# Altium schematic sheet MPD_MINI_DEMO_B1.SchDoc(MPD_MINI_DEMO_B1)
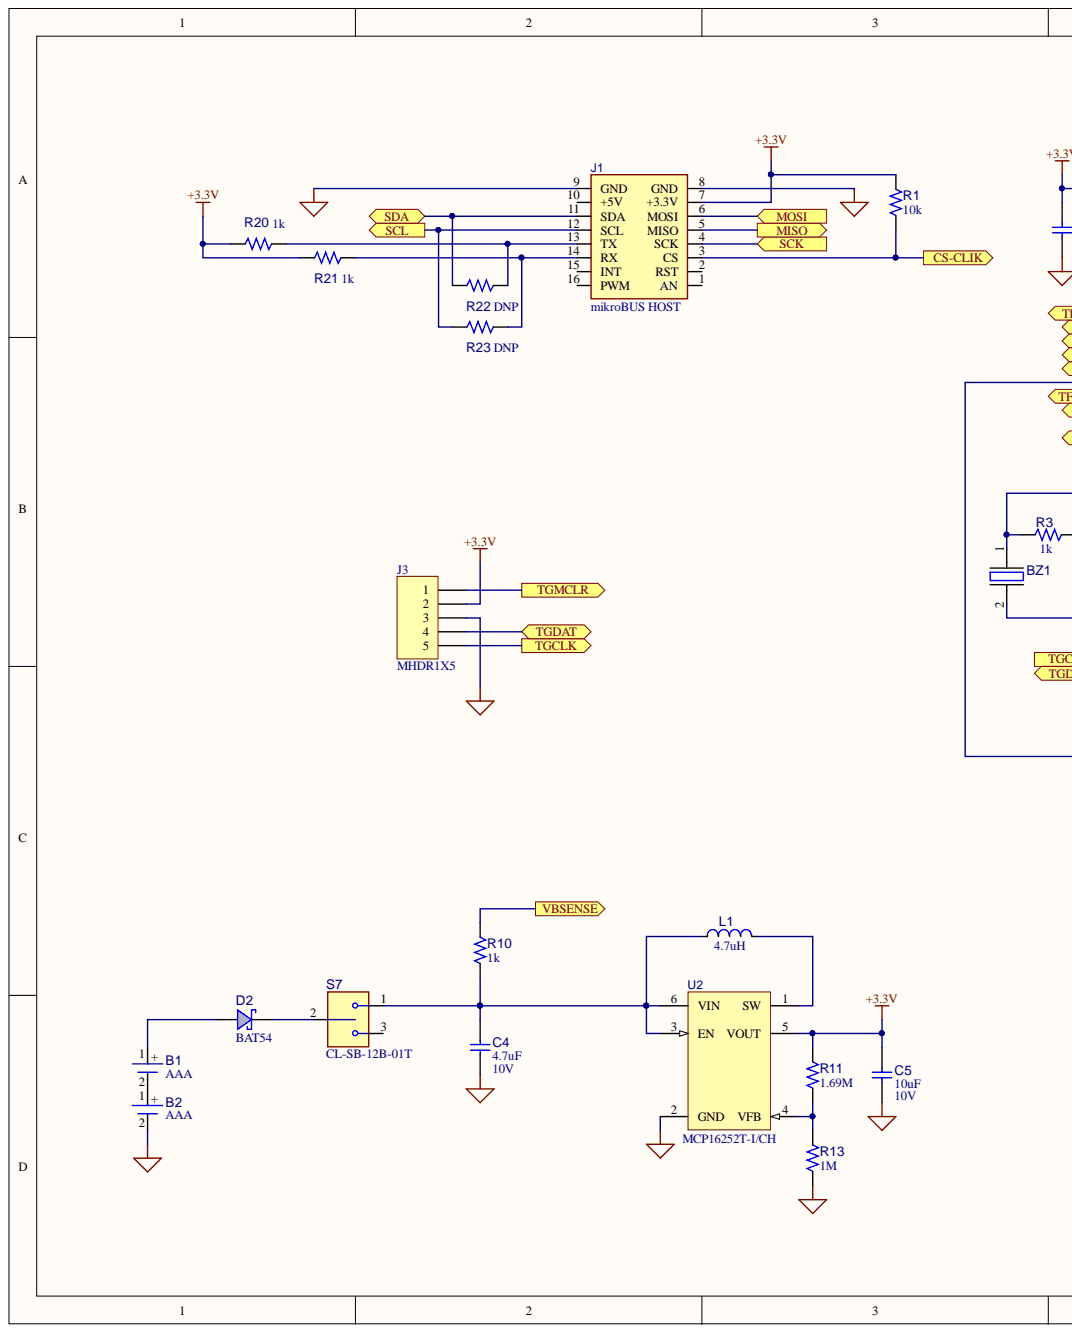
[diagram p0: MPD_MINI_DEMO_B1.SchDoc, left]
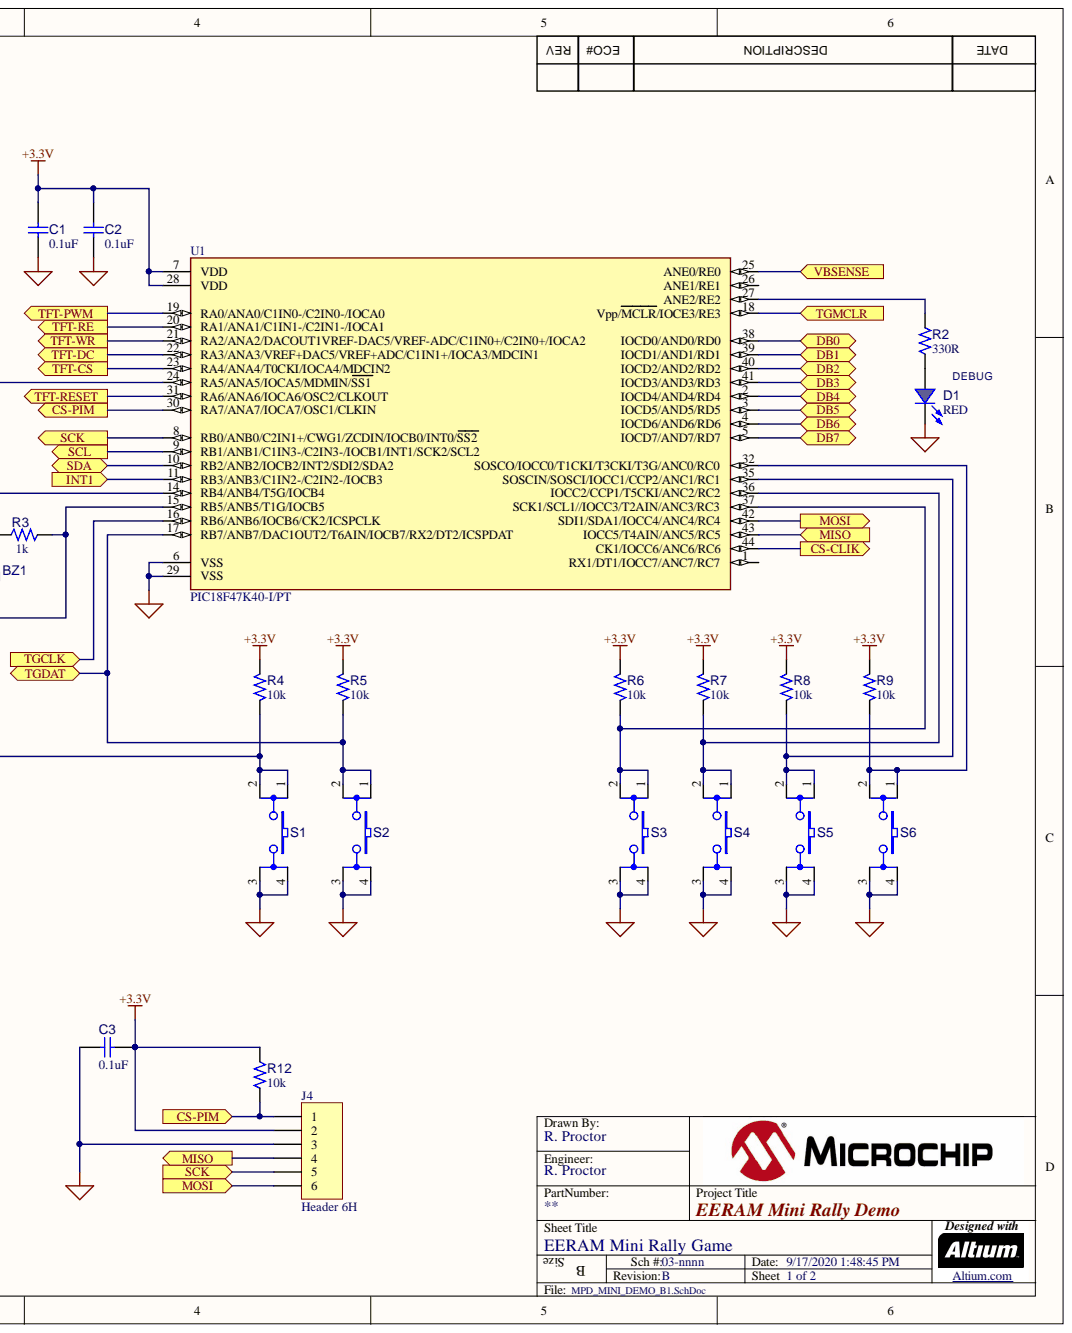
[diagram p1: MPD_MINI_DEMO_B1.SchDoc, right]

## Parts
B1  value AAA  [BATT_HOLDER_1020]  (hidden comment: Battery 2 Pin)
B2  value AAA  [BATT_HOLDER_1020]  (hidden comment: Battery 2 Pin)
BZ1  Buzzer  [BUZZER_PS1240P02CT3]  (value not drawn)
C1  value 0.1uF  [CAP_C_0603]  (hidden comment: Capacitor NP)
C2  value 0.1uF  [CAP_C_0603]  (hidden comment: Capacitor NP)
C3  value 0.1uF  [CAP_C_0603]  (hidden comment: Capacitor NP)
C4  value 4.7uF  Voltage: 10V  [CAP_C_0603]  (hidden comment: Capacitor NP)
C5  value 10uF  Voltage: 10V  [CAP_C_0603]  (hidden comment: Capacitor NP)
D1  value RED  [LED_0603_RED]  (hidden comment: LED)
D2  value BAT54  [SOT-23-3]  (hidden comment: Diode Schottky SOT-23-3)
J1  value mikroBUS HOST  [SOCKET_mikroBUS_F_2.54_2x8_V_25.4x21]  (hidden comment: SOCKET mikroBUS HOST)
J3  MHDR1X5  [MHDR1X5]
J4  Header 6H  [HDR1X6H]
L1  value 4.7uH  [IND_C_2518]  (hidden comment: Inductor)
R1  value 10k  [RES_C_0603]  (hidden comment: Resistor)
R2  value 330R  [RES_C_0603]  (hidden comment: Resistor)
R3  value 1k  [RES_C_0603]  (hidden comment: Resistor)
R4  value 10k  [RES_C_0603]  (hidden comment: Resistor)
R5  value 10k  [RES_C_0603]  (hidden comment: Resistor)
R6  value 10k  [RES_C_0603]  (hidden comment: Resistor)
R7  value 10k  [RES_C_0603]  (hidden comment: Resistor)
R8  value 10k  [RES_C_0603]  (hidden comment: Resistor)
R9  value 10k  [RES_C_0603]  (hidden comment: Resistor)
R10  value 1k  [RES_C_0603]  (hidden comment: Resistor)
R11  value 1.69M  [RES_C_0603]  (hidden comment: Resistor)
R12  value 10k  [RES_C_0603]  (hidden comment: Resistor)
R13  value 1M  [RES_C_0603]  (hidden comment: Resistor)
R20  value 1k  [RES_C_0603]  (hidden comment: Resistor)
R21  value 1k  [RES_C_0603]  (hidden comment: Resistor)
R22  value DNP  [RES_C_0603]  (hidden comment: Resistor)
R23  value DNP  [RES_C_0603]  (hidden comment: Resistor)
S1  Switch 4 Pin  [SW_TACT_SMD_B3S-1000]  (value not drawn)
S2  Switch 4 Pin  [SW_TACT_SMD_B3S-1000]  (value not drawn)
S3  Switch 4 Pin  [SW_TACT_SMD_B3S-1000]  (value not drawn)
S4  Switch 4 Pin  [SW_TACT_SMD_B3S-1000]  (value not drawn)
S5  Switch 4 Pin  [SW_TACT_SMD_B3S-1000]  (value not drawn)
S6  Switch 4 Pin  [SW_TACT_SMD_B3S-1000]  (value not drawn)
S7  Manufacturer Part Number 1: CL-SB-12B-01T  [SW_SLIDE_SMD_CL-SB-12B-01T]  (hidden comment: Switch 3 Pin)
U1  value PIC18F47K40-I/PT  [TQFP-44_10x10]  (hidden comment: PIC18(L)F4XK40 TQFP-44)
U2  MCP16252  Manufacturer Part Number 1: MCP16252T-I/CH  [SOT-23-6]

## Ports
CS-CLIK, CS-PIM, DB0, DB1, DB2, DB3, DB4, DB5, DB6, DB7, INT1, MISO, MOSI, SCK, SCL, SDA, TFT-DC, TFT-PWM, TFT-RE, TFT-RESET, TFT-WR, TFT-~{CS}, TGCLK, TGDAT, TGMCLR, VBSENSE

## Nets
+3.3V: C1.1 C2.1 C3.1 C5.1 J1.7(+3.3V) J3.2 J4.2 R1.1 R4.1 R5.1 R6.1 R7.1 R8.1 R9.1 R11.1 R12.1 R20.1 R21.1 U1.7(VDD) U1.28(VDD) U2.5(VOUT)
GND: B2.2 C1.2 C2.2 C3.2 C4.2 C5.2 D1.2 J1.8(GND) J1.9(GND) J3.3 J4.3 R13.2 S1.3 S1.4 S2.3 S2.4 S3.3 S3.4 S4.3 S4.4 S5.3 S5.4 S6.3 S6.4 U1.6(VSS) U1.29(VSS) U2.2(GND)
CS-CLIK: J1.3(CS) R1.2 U1.44(CK1/IOCC6/ANC6/RC6) ; port CS-CLIK
CS-PIM: J4.1 R12.2 U1.30(RA7/ANA7/IOCA7/OSC1/CLKIN) ; port CS-PIM
DB0: U1.38(IOCD0/AND0/RD0) ; port DB0
DB1: U1.39(IOCD1/AND1/RD1) ; port DB1
DB2: U1.40(IOCD2/AND2/RD2) ; port DB2
DB3: U1.41(IOCD3/AND3/RD3) ; port DB3
DB4: U1.2(IOCD4/AND4/RD4) ; port DB4
DB5: U1.3(IOCD5/AND5/RD5) ; port DB5
DB6: U1.4(IOCD6/AND6/RD6) ; port DB6
DB7: U1.5(IOCD7/AND7/RD7) ; port DB7
INT1: U1.11(RB3/ANB3/C1IN2-/C2IN2-/IOCB3) ; port INT1
MISO: J1.5(MISO) J4.4 U1.43(IOCC5/T4AIN/ANC5/RC5) ; port MISO
MOSI: J1.6(MOSI) J4.6 U1.42(SDI1/SDA1/IOCC4/ANC4/RC4) ; port MOSI
SCK: J1.4(SCK) J4.5 U1.8(RB0/ANB0/C2IN1+/CWG1/ZCDIN/IOCB0/INT0/SS2) ; port SCK
SCL: J1.12(SCL) R23.1 U1.9(RB1/ANB1/C1IN3-/C2IN3-/IOCB1/INT1/SCK2/SCL2) ; port SCL
SDA: J1.11(SDA) R22.1 U1.10(RB2/ANB2/IOCB2/INT2/SDI2/SDA2) ; port SDA
TFT-~{CS}: U1.23(RA4/ANA4/T0CKI/IOCA4/MDCIN2) ; port TFT-~{CS}
TFT-DC: U1.22(RA3/ANA3/VREF+DAC5/VREF+ADC/C1IN1+/IOCA3/MDCIN1) ; port TFT-DC
TFT-PWM: U1.19(RA0/ANA0/C1IN0-/C2IN0-/IOCA0) ; port TFT-PWM
TFT-RE: U1.20(RA1/ANA1/C1IN1-/C2IN1-/IOCA1) ; port TFT-RE
TFT-RESET: U1.31(RA6/ANA6/IOCA6/OSC2/CLKOUT) ; port TFT-RESET
TFT-WR: U1.21(RA2/ANA2/DACOUT1VREF-DAC5/VREF-ADC/C1IN0+/C2IN0+/IOCA2) ; port TFT-WR
TGCLK: J3.5 U1.16(RB6/ANB6/IOCB6/CK2/ICSPCLK) ; port TGCLK
TGDAT: J3.4 R5.2 S2.1 S2.2 U1.17(RB7/ANB7/DAC1OUT2/T6AIN/IOCB7/RX2/DT2/ICSPDAT) ; port TGDAT
TGMCLR: J3.1 U1.18(Vpp/MCLR/IOCE3/RE3) ; port TGMCLR
VBSENSE: R10.1 U1.25(ANE0/RE0) ; port VBSENSE
NetB1_1: B1.1 D2.1
NetB1_2: B1.2 B2.1
NetBZ1_1: BZ1.1 R3.1 U1.14(RB4/ANB4/T5G/IOCB4)
NetBZ1_2: BZ1.2 R3.2 U1.15(RB5/ANB5/T1G/IOCB5)
NetC4_1: C4.1 L1.1 R10.2 S7.1 U2.3(EN) U2.6(VIN)
NetD1_1: D1.1 R2.2
NetD2_3: D2.3 S7.2
NetJ1_13: J1.13(TX) R20.2 R22.2
NetJ1_14: J1.14(RX) R21.2 R23.2
NetL1_2: L1.2 U2.1(SW)
NetR2_1: R2.1 U1.27(ANE2/RE2)
NetR4_2: R4.2 S1.1 S1.2 U1.24(RA5/ANA5/IOCA5/MDMIN/SS1)
NetR6_2: R6.2 S3.1 S3.2 U1.37(SCK1/SCL1//IOCC3/T2AIN/ANC3/RC3)
NetR7_2: R7.2 S4.1 S4.2 U1.36(IOCC2/CCP1/T5CKI/ANC2/RC2)
NetR8_2: R8.2 S5.1 S5.2 U1.35(SOSCIN/SOSCI/IOCC1/CCP2/ANC1/RC1)
NetR9_2: R9.2 S6.1 S6.2 U1.32(SOSCO/IOCC0/T1CKI/T3CKI/T3G/ANC0/RC0)
NetR11_2: R11.2 R13.1 U2.4(VFB)

Not connected: J1 1(AN) 2(RST) 10(+5V) 15(INT) 16(PWM); S7 3; U1 1(RX1/DT1/IOCC7/ANC7/RC7) 26(ANE1/RE1)

## Library data of the parts
B1 B2 = BAT1020: Battery; Manufacturer Part Number 1: 1020; Manufacturer 1: Keystone Electronics; Populated: YES; Owner: Jamus Griego; Dev Tools Status: Design; Description_: BATT HOLDER 1CELL SMD AAA; Type: Holder; Symbol: Battery 2 Pin; Supplier Part Number 1: 36-1020-ND; Supplier 1: Digi-Key; Status: MCL Design; Revision: A; Quantity Override: 1; MCHP-ID: BAT1020; Library Name: MCHP-CDB.DbLib; Database Table Name: Battery; ComponentLink1URL: https://chn-vm-apps.microchip.com/mchprepo/parts/Datasheets/1020.PDF; ComponentLink1Description: Datasheets; Component Kind: Standard; Category: Battery
BZ1 = MECH0133: Manufacturer 1: TDK Corporation; Value: PS1240P02CT3; Populated: YES; Owner: Mark Loper; Dev Tools Status: Design; Description_: MECH HW Buzzer Single Tone 4kHz Piezo 30V SMD; Database Table Name: Mechanical; Type: Buzzer; Symbol: Buzzer; Supplier Part Number 1: 445-5229-1-ND; Supplier 1: Digi-Key; Status: MCL Design; Revision: A; Quantity Override: 1; MCHP-ID: MECH0133; Manufacturer Part Number 1: PS1240P02CT3; Library Name: MCHP-CDB.DbLib; ComponentLink1URL: https://chn-vm-apps.microchip.com/mchprepo/parts/Datasheets/ef532_ps.pdf; ComponentLink1Description: Datasheet; Component Kind: Standard; Category: Hardware
C1-C3 = CAP1047: Capacitor; Manufacturer Part Number 1: C1608X7R1H104M; Manufacturer 1: TDK; Voltage: 50V; Type: Ceramic; Tolerance: 20%; Temperature Coeffcient: X7R; Populated: Yes; Owner: Leah Whitaker; Dev Tools Status: Production; Description_: CAP CER 0.1uF 50V 20% X7R SMD 0603; Symbol: Capacitor NP; Supplier Part Number 2: C1608X7R1H104M080AA; Supplier Part Number 1: 445-5098-1-ND; Supplier 2: TDK; Supplier 1: Digi-Key; Status: MCL Design; Revision: 1; Quantity Override: 1; Package: 0603; Operating Temperature: -55C to +125C; MCHP-ID: CAP1047; Manufacturer Part Number 2: C1608X7R1H104M080AA; Manufacturer 2: TDK; Library Name: MCHP-CDB.DbLib; Database Table Name: Capacitor; ComponentLink1URL: https://chn-vm-apps.microchip.com/mchprepo/parts/Datasheets/general_B11.pdf; ComponentLink1Description: Datasheet; Component Kind: Standard; Category: Capacitor
C4 = CAP0543: Capacitor; Manufacturer Part Number 1: C0603C475K8PACTU; Manufacturer 1: KEMET; Type: Ceramic; Tolerance: 10%; Temperature Coeffcient: X5R; Populated: Yes; Dev Tools Status: Production; Description_: CAP CER 4.7uF 10V 10% X5R SMD 0603; Symbol: Capacitor NP; Supplier Part Number 3: 587-1441-1-ND; Supplier Part Number 2: 445-5170-1-ND; Supplier Part Number 1: 399-5503-1-ND; Supplier 3: Digi-Key; Supplier 2: Digi-Key; Supplier 1: Digi-Key; Status: MCL Design; Revision: 1; Quantity Override: 1; Package: 0603; Operating Temperature: -55C to +85C; MCHP-ID: CAP0543; Manufacturer Part Number 3: LMK107BJ475KA-T; Manufacturer Part Number 2: C1608X5R1A475K080AC; Manufacturer 3: Taiyo Yuden; Manufacturer 2: TDK Corporation; Library Name: MCHP-CDB.DbLib; Database Table Name: Capacitor; ComponentLink1URL: https://chn-vm-apps.microchip.com/mchprepo/parts/Datasheets/mlcc01_e.pdf; ComponentLink1Description: Datasheet; Component Kind: Standard; Category: Capacitor
C5 = CAP0542: Capacitor; Manufacturer Part Number 1: ECJ-1VB1A106M; Manufacturer 1: Panasonic; Type: Ceramic; Tolerance: 20%; Temperature Coeffcient: X5R; Populated: Yes; Dev Tools Status: Production; Description_: CAP CER 10uF 10V 20% X5R SMD 0603; Symbol: Capacitor NP; Supplier Part Number 2: 445-6853-1-ND; Supplier Part Number 1: PCC2479CT-ND; Supplier 2: Digi-Key; Supplier 1: Digi-Key; Status: MCL Design; Revision: 2; Quantity Override: 1; Package: 0603; Operating Temperature: -55C to +85C; MCHP-ID: CAP0542; Manufacturer Part Number 2: C1608X5R1A106M; Manufacturer 2: TDK Corporation; Library Name: MCHP-CDB.DbLib; Database Table Name: Capacitor; ComponentLink1URL: https://chn-vm-apps.microchip.com/mchprepo/parts/Datasheets/ECJ__General_.pdf; ComponentLink1Description: Datasheet; Component Kind: Standard; Category: Capacitor
D1 = DIODE1058: Manufacturer Part Number 1: LTST-C190EKT; Manufacturer 1: Lite-On; Type: LED Single; Reverse Voltage: 5V; Reverse Current: 100uA; Power: 100mW; Populated: YES; Owner: Tad Ahlvers; Forward Current: 30mA; Dev Tools Status: Production; Description_: DIO LED RED 2V 30mA 2mcd Clear SMD 0603; Database Table Name: Diode; Symbol: LED; Supplier Part Number 1: 160-1182-1-ND; Supplier 1: Digi-Key; Status: MCL Design; Revision: 1; Quantity Override: 1; Package: 0603; Operating Temperature: -55C to +85C; MCHP-ID: DIODE1058; Library Name: MCHP-CDB.DbLib; Description: LED; ComponentLink1URL: https://chn-vm-apps.microchip.com/mchprepo/parts/Datasheets/LTST-C190EKT.pdf; ComponentLink1Description: Datasheet; Component Kind: Standard; Category: Diode
D2 = DIODE0099: Manufacturer Part Number 1: BAT54-7; Manufacturer 1: Diodes Incorporated; Type: Schottky Single; Reverse Voltage: 30V; Reverse Current: 2uA; Power: 200mW; Populated: Yes; Forward Current: 200mA; Dev Tools Status: Production; Description_: DIO SCTKY BAT54 800mV 200mA 30V SOT-23-3; Symbol: Diode Schottky SOT-23-3; Supplier Part Number 4: BAT54-7-F; Supplier Part Number 3: BAT54FSTR-ND; Supplier Part Number 2: BAT54FSCT-ND; Supplier Part Number 1: BAT54DICT-ND; Supplier 4: Diodes Incorporated; Supplier 3: Digi-Key; Supplier 2: Digi-Key; Supplier 1: Digi-Key; Status: MCL Design; Revision: 1; Quantity Override: 1; Package: SOT-23-3; Operating Temperature: -65C to +150C; MCHP-ID: DIODE0099; Manufacturer Part Number 3: BAT54-7-F; Manufacturer Part Number 2: BAT54; Manufacturer 3: Diodes Incorporated; Manufacturer 2: Fairchild; Library Name: MCHP-CDB-Offline.DbLib; Database Table Name: Diode; ComponentLink7Description: Open Footprint 4; ComponentLink6Description: Open Footprint 3; ComponentLink5Description: Open Footprint 2; ComponentLink4Description: Open Footprint; ComponentLink3Description: Open Symbol; ComponentLink2Description: Local Datasheet; ComponentLink1URL: https://chn-vm-apps.microchip.com/mchprepo/parts/Datasheets/ds11005.pdf; ComponentLink1Description: SVN Datasheet; Component Kind: Standard; Category: Diode; ComponentLink4URL: C:\ALTIUM_WORK\00001_MCHP-CDB\Library\Footprints\SOT-23-3.PcbLib; ComponentLink3URL: C:\ALTIUM_WORK\00001_MCHP-CDB\Library\LibraryRefs\Diode Schottky SOT-23-3.SchLib; ComponentLink2URL: C:\ALTIUM_WORK\00001_MCHP-CDB\Datasheets\ds11005.pdf
J1 = SKT1042: Manufacturer Part Number 1: PPTC081LFBN-RC; Manufacturer 1: Sullins Connector Solutions; Populated: YES; Owner: Stephen Humberd; Dev Tools Status: Production; Description_: SOCKET mikroBUS HOST DIP 16 TH; Type: MikroBUS; Symbol: SOCKET mikroBUS HOST; Supplier Part Number 2: Nextron R-212-0810-0071-000; Supplier Part Number 1: S7006-ND; Supplier 2: Raynen; Supplier 1: Digi-Key; Status: MCL Design; Revision: 1; Quantity Override: 2; Package: mikroBUS HOST; MCHP-ID: SKT1042; Manufacturer Part Number 2: R-212-0810-0071-000; Manufacturer 2: Nextron; Library Name: MCHP-CDB-Offline.DbLib; Database Table Name: Socket; ComponentLink7Description: Open Footprint 4; ComponentLink6Description: Open Footprint 3; ComponentLink5Description: Open Footprint 2; ComponentLink4Description: Open Footprint; ComponentLink3Description: Open Symbol; ComponentLink2Description: Local Datasheet; ComponentLink1URL: https://chn-vm-apps.microchip.com/mchprepo/parts/Datasheets/mikrobus_specification.pdf; ComponentLink1Description: SVN Datasheet; Component Kind: Standard; Category: Socket; ComponentLink7URL: C:\ALTIUM_WORK\00001_MCHP-CDB\Library\Footprints\SOCKET_mikroBUS_F_2.54_2x8_V_25.4x21_LOGO.PcbLib; ComponentLink4URL: C:\ALTIUM_WORK\00001_MCHP-CDB\Library\Footprints\SOCKET_mikroBUS_F_2.54_2x8_V_25.4x21.PcbLib; ComponentLink3URL: C:\ALTIUM_WORK\00001_MCHP-CDB\Library\LibraryRefs\SOCKET mikroBUS HOST.SchLib; ComponentLink2URL: C:\ALTIUM_WORK\00001_MCHP-CDB\Datasheets\mikrobus_specification.pdf
J3 = MHDR1X5: Header, 5-Pin; Signal Integrity: Connector; Library Name: Miscellaneous Connectors.IntLib; LatestRevisionNote: Re-released for DXP Platform.; LatestRevisionDate: 17-Jul-2002; Component Kind: Standard; Publisher: Altium Limited
J4 = Header 6H: Header, 6-Pin, Right Angle; Signal Integrity: Connector; Library Name: Miscellaneous Connectors.IntLib; LatestRevisionNote: Re-released for DXP Platform.; LatestRevisionDate: 17-Jul-2002; Component Kind: Standard; Publisher: Altium Limited
L1 = IND1220: Manufacturer Part Number 1: CBC2518T4R7M; Manufacturer 1: Taiyo Yuden; Test Frequency: 7.96MHz; Saturation Current: 680mA; Populated: YES; Owner: Duane Burt; Dev Tools Status: Production; Description_: IND 4.7UH 920MA 260 MOHM; Type: Inductor; Symbol: Inductor; Supplier Part Number 1: 587-1621-1-ND; Supplier 1: Digikey; Status: MCL Design; Revision: 1; Quantity Override: 1; Package: 1007 (2518mm); MCHP-ID: IND1220; Library Name: MCHP-CDB.DbLib; Description: Inductor; Database Table Name: Inductor; Component Kind: Standard; Category: Inductor
R1 R4-R9 R12 = RSMT0139: Manufacturer Part Number 1: ERJ-3EKF1002V; Manufacturer 1: Panasonic; Tolerance: 1%; Temperature Coeffcient: 100ppm/C; Power: 1/10W; Populated: Yes; Dev Tools Status: Production; Description_: RES TKF 10k 1% 1/10W SMD 0603; Type: RES SMD; Symbol: Resistor; Supplier Part Number 6: NRC06F1002TRF; Supplier Part Number 5: 302-10k-RC; Supplier Part Number 4: RMCF0603FT10K0TR-ND; Supplier Part Number 3: 311-10.0KHRCT-ND; Supplier Part Number 2: RMCF1/1610KFRTR-ND; Supplier Part Number 1: P10.0KHCT-ND; Supplier 6: NIC Components; Supplier 5: Mouser; Supplier 4: Digi-Key; Supplier 3: Digi-Key; Supplier 2: Digi-Key; Supplier 1: Digi-Key; Status: MCL Design; Revision: 2; Quantity Override: 1; Package: 0603; Operating Temperature: -55C to +155C; MCHP-ID: RSMT0139; Manufacturer Part Number 6: NRC06F1002TRF; Manufacturer Part Number 5: 302-10K-RC; Manufacturer Part Number 4: RMCF 1/16 10K 1% R; Manufacturer Part Number 3: RC0603FR-0710KL; Manufacturer Part Number 2: RMCF0603FT10K0; Manufacturer 6: NIC Components; Manufacturer 5: Xicon; Manufacturer 4: Stackpole Electronics Inc; Manufacturer 3: Yageo; Manufacturer 2: Stackpole Electronics Inc; Library Name: MCHP-CDB.DbLib; Description: Resistor; Database Table Name: Resistor; Composition: TKF; ComponentLink1URL: https://chn-vm-apps.microchip.com/mchprepo/parts/Datasheets/nrc.pdf; ComponentLink1Description: Datasheet; Component Kind: Standard; Category: Resistor
R2 = RSMT0626: Manufacturer Part Number 1: ERJ-3EKF3300V; Manufacturer 1: Panasonic; Voltage: 75V; Tolerance: 1%; Temperature Coeffcient: 100ppm/C; Power: 1/10W; Populated: Yes; Dev Tools Status: Production; Description_: RES TKF 330R 1% 1/10W SMD 0603; Type: RES SMD; Symbol: Resistor; Supplier Part Number 2: RMCF0603FT330RCT-ND; Supplier Part Number 1: P330HCT-ND; Supplier 2: Digi-Key; Supplier 1: Digi-Key; Status: MCL Design; Revision: 2; Quantity Override: 1; Package: 0603; Operating Temperature: -55C to +155C; MCHP-ID: RSMT0626; Manufacturer Part Number 2: RMCF0603FT330R; Manufacturer 2: Stackpole Electronics Inc; Library Name: MCHP-CDB.DbLib; Description: Resistor; Database Table Name: Resistor; Composition: TKF; ComponentLink1URL: https://chn-vm-apps.microchip.com/mchprepo/parts/Datasheets/AOA0000CE2.pdf; ComponentLink1Description: Datasheet; Component Kind: Standard; Category: Resistor
R3 R10 R20-R23 = RSMT0138: Manufacturer Part Number 1: ERJ-3EKF1001V; Manufacturer 1: Panasonic; Voltage: 75V; Tolerance: 1%; Temperature Coeffcient: 100ppm/C; Power: 1/10W; Populated: Yes; Dev Tools Status: Production; Description_: RES TKF 1k 1% 1/10W SMD 0603; Type: RES SMD; Symbol: Resistor; Supplier Part Number 9: A106049CT-ND; Supplier Part Number 8: RMCF1/161.0K1%TR; Supplier Part Number 7: 52K8015; Supplier Part Number 6: RMCF0603FT1K00TR-ND; Supplier Part Number 5: RMCF1/161KFRTR-ND; Supplier Part Number 4: RHM1.00KHCT-ND; Supplier Part Number 3: 311-1.00KHRCT-ND; Supplier Part Number 2: P1.00KHTR-ND; Supplier Part Number 1: P1.00KHCT-ND; Supplier 9: Digi-Key; Supplier 8: Stackpole Electronics Inc; Supplier 7: Newark; Supplier 6: Digi-Key; Supplier 5: Digi-Key; Supplier 4: Digi-Key; Supplier 3: Digi-Key; Supplier 2: Digi-Key; Supplier 1: Digi-Key; Status: MCL Design; Revision: 2; Quantity Override: 1; Package: 0603; Operating Temperature: -55C to +155C; MCHP-ID: RSMT0138; Manufacturer Part Number 8: 1622866-1; Manufacturer Part Number 7: RMCF1/161.0K1%TR; Manufacturer Part Number 6: CRCW06031K00FKEA; Manufacturer Part Number 5: RMCF 1/16 1K 1% R; Manufacturer Part Number 4: RMCF0603FT1K00; Manufacturer Part Number 3: MCR03EZPFX1001; Manufacturer Part Number 2: RC0603FR-071KL; Manufacturer 8: TE Connectivity; Manufacturer 7: Stackpole Electronics Inc; Manufacturer 6: Vishay; Manufacturer 5: Stackpole Electronics Inc; Manufacturer 4: Stackpole Electronics Inc; Manufacturer 3: ROHM; Manufacturer 2: Yageo; Library Name: MCHP-CDB.DbLib; Description: Resistor; Database Table Name: Resistor; Composition: TKF; ComponentLink1URL: https://chn-vm-apps.microchip.com/mchprepo/parts/Datasheets/AOA0000CE2.pdf; ComponentLink1Description: Datasheet; Component Kind: Standard; Category: Resistor
R11 = RSMT1114: Manufacturer Part Number 1: CRCW06031M69FKEA; Manufacturer 1: Vishay Dale; Voltage: 75V; Tolerance: 1%; Temperature Coeffcient: 100ppm/C; Power: 1/10W; Populated: YES; Owner: Bogdan Popescu; Dev Tools Status: Production; Description_: RES TKF 1.69M 1% 1/10W SMD 0603; Type: RES SMD; Symbol: Resistor; Supplier Part Number 1: 541-1.69MHCT-ND; Supplier 1: Digi-Key; Status: MCL Design; Revision: 1; Quantity Override: 1; Package: 0603; Operating Temperature: -55C to +155C; MCHP-ID: RSMT1114; Library Name: MCHP-CDB.DbLib; Description: Resistor; Database Table Name: Resistor; Composition: TKF; ComponentLink1URL: https://chn-vm-apps.microchip.com/mchprepo/parts/Datasheets/dcrcwe3.pdf; ComponentLink1Description: Datasheet; Component Kind: Standard; Category: Resistor
R13 = RSMT0398: Manufacturer Part Number 1: ERJ-3EKF1004V; Manufacturer 1: Panasonic; Voltage: 50V; Tolerance: 1%; Temperature Coeffcient: 100ppm/C; Power: 1/10W; Populated: Yes; Dev Tools Status: Production; Description_: RES TKF 1M 1% 1/10W SMD 0603; Type: RES SMD; Symbol: Resistor; Supplier Part Number 3: 311-1.00MHTR-ND; Supplier Part Number 2: RHM1.00MHCT-ND; Supplier Part Number 1: P1.00MHCT-ND; Supplier 3: Digi-Key; Supplier 2: Digi-Key; Supplier 1: Digi-Key; Status: MCL Design; Revision: A; Quantity Override: 1; Package: 0603; Operating Temperature: -55C to +155C; MCHP-ID: RSMT0398; Manufacturer Part Number 3: 9C06031A1004FKHFT; Manufacturer Part Number 2: MCR03EZPFX1004; Manufacturer 3: Yageo; Manufacturer 2: ROHM; Library Name: MCHP-CDB.DbLib; Description: Resistor; Database Table Name: Resistor; Composition: TKF; ComponentLink1URL: https://chn-vm-apps.microchip.com/mchprepo/parts/Datasheets/PYu-RC0603_51_RoHS_L_4.pdf; ComponentLink1Description: Datasheet; Component Kind: Standard; Category: Resistor
S1-S6 = SWITCH1046: Switch; Manufacturer 1: C&K Components; Value: PTS645SM43SMTR92 LFS; Populated: YES; Owner: Jamus Griego; Dev Tools Status: Production; Description_: SWITCH TACT SPST 12V 50mA PTS645SM43SMTR92 LFS SMD; Type: Tactile; Symbol: Switch 4 Pin; Supplier Part Number 2: SWITECH TS2A-043C-G9; Supplier Part Number 1: CKN9112CT-ND; Supplier 2: Raynen; Supplier 1: Digi-Key; Status: MCL Design; Revision: 1; Quantity Override: 1; MCHP-ID: SWITCH1046; Manufacturer Part Number 2: TS2A-043C-G9; Manufacturer Part Number 1: PTS645SM43SMTR92 LFS; Manufacturer 2: SWITECH; Library Name: MCHP-CDB.DbLib; Database Table Name: Switch; ComponentLink1URL: https://chn-vm-apps.microchip.com/mchprepo/parts/Datasheets/PTS645_17dec14.pdf; ComponentLink1Description: Datasheet; Component Kind: Standard; Category: Switch
S7 = SWITCH1056: Switch; Manufacturer 1: Copal Electronics Inc.; Voltage: 12V; Populated: Yes; Owner: Mark Loper; Dev Tools Status: Design; Description_: SWITCH SLIDE SPDT 12V 200MA CL-SB-12B-01T SMD; Current: 200mA; Symbol: SWITCH 3 PIN; Supplier Part Number 1: 563-1318-1-ND; Supplier 1: Digi-Key; Status: MCL Design; Revision: A; Quantity Override: 1; MCHP-ID: SWITCH1056; Library Name: MCHP-CDB.DbLib; Database Table Name: Switch; ComponentLink1Description: Datasheet; Component Kind: Standard; Category: Switch
U1 = MIC6680: Manufacturer 1: Microchip Production; Populated: YES; Owner: Mark Loper; Dev Tools Status: Design; Description_: MCHP MCU 8-BIT 64MHZ 64kB 1kB PIC18F47K40-I/PT; Database Table Name: MCHP-Microcontroller; Type: 8-Bit; Symbol: PIC18(L)F4XK40 TQFP-44; Supplier Part Number 1: MIC6680; Supplier 1: Microchip Production; Status: MCL Design; Revision: A; Quantity Override: 1; MCHP-ID: MIC6680; Manufacturer Part Number 1: MIC6680; Library Name: MCHP-CDB.DbLib; ComponentLink1Description: Datasheet; Component Kind: Standard; Category: MCHP-Microcontroller
U2 = MIC6420: MCP16251T-I/CH; Manufacturer 1: Microchip Technology Inc; VoutMin: 1.8; VoutMax: 5.5; Value: MCP16252T-I/CH-ND; TempRange: -40C to +85C; tblDeviceFamilyID: 3543; SwitchingFrequency: 500; Stage: REL; QuiescentCurrent: 0.004; Populated: YES; PackingMedia: T/R; Owner: Duane Burt; MPC: NBAD1TENXB00; MinOrderQty: 25; MAPS Description: Low Quiescent current Synchronous boost Cnvtr.131K Iso; LeadCount: 6; LeadContent: Pb-FREE; InputVoltageRangeMin: 0.82; ForceMinOrderQtyMult: True; Dev Tools Status: Production; Description_: IC REG BST ADJ 0.1A SYNC SOT23-6 MCP16252T-I/CH; Database Table Name: MCHP-Analog; Type: Voltage Regulator; Symbol: MCP16252; Supplier Part Number 1: MCP16252T-I/CH-ND; Supplier 1: Digikey; Status: MCL Design; Revision: 1; Quantity Override: 1; PackageType: SOT-23; MCHP-ID: MIC6420; Library Name: MCHP-CDB.DbLib; IntroductionDate: 2013-04-01; InputVoltageRangeMax: 5.5; ComponentLink1URL: http://www.microchip.com/wwwproducts/Devices.aspx?product=MCP16252; ComponentLink1Description: Datasheet; Component Kind: Standard; Category: MCHP-Analog
